# Altium schematic sheet Sync Demod.SchDoc
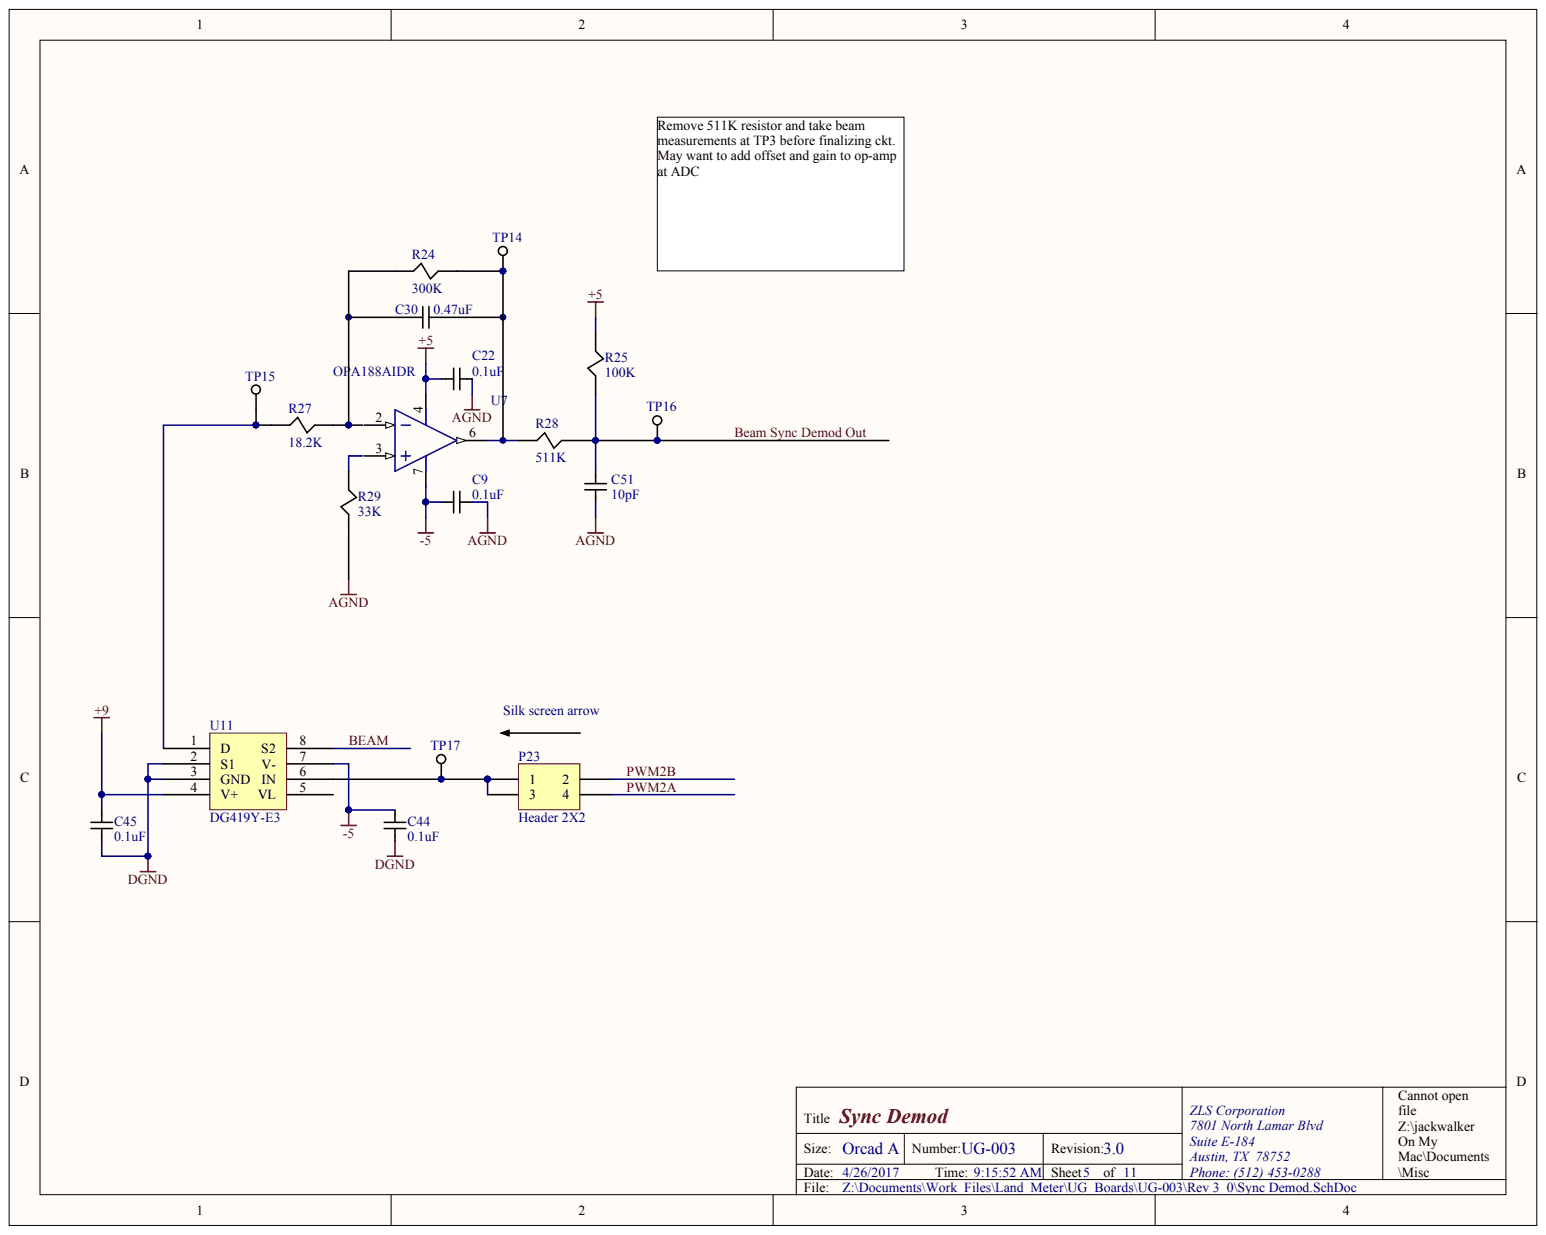
[diagram p0: Sync Demod.SchDoc]

## Parts
C9  0.1uF
C22  0.1uF
C30  0.47uF
C44  0.1uF
C45  0.1uF
C51  10pF
P23  Header 2X2  Connector Type: Header
R24  300K
R25  100K
R27  18.2K
R28  511K
R29  33K
TP14  (no value)
TP15  (no value)
TP16  (no value)
TP17  (no value)
U7  OPA188AIDR
U11  DG419Y-E3

## Nets
+5: C22.1 R25.2 U7.4
+9: C45.1 U11.4(V+)
-5: C9.1 C44.1 U7.7 U11.7(V-)
AGND: C9.2 C22.2 C51.2 R29.1
DGND: C44.2 C45.2 U11.2(S1) U11.3(GND)
BEAM: U11.8(S2)
BEAM SYNC DEMOD OUT: C51.1 R25.1 R28.2 TP16.1
PWM2A: P23.4
PWM2B: P23.2
NetC30_1: C30.1 R24.1 R27.2
NetC30_2: C30.2 R24.2 R28.1 TP14.1 U7.6
NetP23_1: P23.1 P23.3 TP17.1 U11.6(IN)
NetR27_1: R27.1 TP15.1 U11.1(D)

Not connected: R29 2; U7 2 3; U11 5(VL)

## Library data of the parts
C9 C22 C44 C45 = (no symbol name): Manufacturer 1: Murata Electronics North America; Manufacturer Part Number 1: GRM21BR71E104KA01L; Description 1: CAP CER 0.1UF 25V X7R 0805; Category 1: Capacitors; Capacitance: 0.1µF; Tolerance: ±10%; Voltage - Rated: 25V; Temperature Coefficient: X7R; Supplier 1: Digi-Key; Supplier Part Number 1: 490-1673-1-ND; RoHS 1: RoHS Compliant; Stock 1: 897437; Pricing 1: 1=0.2, 10=0.145, 25=0.106, 50=0.0824, 100=0.0683, 250=0.05652, 500=0.04788, 1000=0.03964 (USD); Packaging: Cut Tape (CT); Part Status: Active; Operating Temperature: -55°C ~ 125°C; Features: -; Ratings: -; Applications: General Purpose; Mounting Type: Surface Mount, MLCC; Package / Case: 0805 (2012 Metric); Size / Dimension: 0.079" L x 0.049" W (2.00mm x 1.25mm); Height - Seated (Max): -; Thickness (Max): 0.053" (1.35mm); Lead Spacing: -; Lead Style: -; ComponentLink1URL: http://www.murata.com/~/media/webrenewal/support/library/catalog/products/capacitor/mlcc/c02e.ashx; ComponentLink1Description: http://www.murata.com/~/media/webrenewal/support/library/catalog/products/capacitor/mlcc/c02e.ashx
C30 = (no symbol name): Manufacturer 1: Murata Electronics North America; Manufacturer Part Number 1: GRM219R71E474KA88D; Description 1: CAP CER 0.47UF 25V X7R 0805; Category 1: Capacitors; Capacitance: 0.47µF; Tolerance: ±10%; Voltage - Rated: 25V; Temperature Coefficient: X7R; Supplier 1: Digi-Key; Supplier Part Number 1: 490-11584-1-ND; RoHS 1: RoHS Compliant; Stock 1: 32625; Pricing 1: 1=0.31, 10=0.219, 25=0.1792, 50=0.153, 100=0.1311, 250=0.10924, 500=0.09832, 1000=0.08303 (USD); Packaging: Cut Tape (CT); Part Status: Active; Operating Temperature: -55°C ~ 125°C; Features: -; Ratings: -; Applications: General Purpose; Mounting Type: Surface Mount, MLCC; Package / Case: 0805 (2012 Metric); Size / Dimension: 0.079" L x 0.049" W (2.00mm x 1.25mm); Height - Seated (Max): -; Thickness (Max): 0.037" (0.95mm); Lead Spacing: -; Lead Style: -; ComponentLink1URL: http://www.murata.com/~/media/webrenewal/support/library/catalog/products/capacitor/mlcc/c02e.ashx; ComponentLink1Description: http://www.murata.com/~/media/webrenewal/support/library/catalog/products/capacitor/mlcc/c02e.ashx
C51 = (no symbol name): Manufacturer 1: Murata Electronics North America; Manufacturer Part Number 1: GRM21A5C2D100JW01D; Description 1: CAP CER 10PF 200V C0G/NP0 0805; Category 1: Capacitors; Tolerance: ±5%; Voltage - Rated: 200V; Temperature Coefficient: C0G, NP0; Supplier 1: Digi-Key; Supplier Part Number 1: 490-14649-1-ND; RoHS 1: RoHS Compliant; Stock 1: 1350; Pricing 1: 1=0.29, 10=0.196, 25=0.1436, 50=0.1174, 100=0.0991, 250=0.08084, 500=0.06782, 1000=0.05999 (USD); Part Status: Active; Capacitance: 10pF; Operating Temperature: -55°C ~ 125°C; Features: -; Ratings: -; Applications: General Purpose; Failure Rate: -; Mounting Type: Surface Mount, MLCC; Package / Case: 0805 (2012 Metric); Size / Dimension: 0.079" L x 0.049" W (2.00mm x 1.25mm); Height - Seated (Max): -; Thickness (Max): 0.039" (1.00mm); Lead Spacing: -; Lead Style: -; ComponentLink1URL: http://search.murata.co.jp/Ceramy/image/img/A01X/G101/ENG/GRM21A5C2D100JW01-01.pdf; ComponentLink1Description: http://search.murata.co.jp/Ceramy/image/img/A01X/G101/ENG/GRM21A5C2D100JW01-01.pdf
P23 = (no symbol name): Manufacturer 1: TE Connectivity AMP Connectors; Manufacturer Part Number 1: 1-87215-0; Description 1: CONN HEADER VERT 2POS .100 30AU; Category 1: Connectors, Interconnects; Style: Board to Board or Cable; Shrouding: Unshrouded; Number of Positions: 2; Number of Positions Loaded: All; Row Spacing - Mating: 0.100" (2.54mm); Contact Length - Mating: 0.318" (8.08mm); Contact Length - Post: 0.125" (3.18mm); Overall Contact Length: 0.533" (13.55mm); Material Flammability Rating: UL94 V-0; Current Rating: 3A; Supplier 1: Digi-Key; Supplier Part Number 1: A26564-ND; RoHS 1: RoHS Compliant; Stock 1: 9703; Pricing 1: 1=1.42, 10=1.287, 50=1.1554, 100=1.1029, 250=0.99784, 500=0.91906, 1000=0.78777, 2500=0.73525 (USD); Packaging: Bulk; Part Status: Active; Contact Type: Male Pin; Pitch - Mating: -; Number of Rows: 2; Mounting Type: Through Hole; Termination: Solder; Fastening Type: Push-Pull; Insulation Height: 0.090" (2.29mm); Contact Shape: Circular; Contact Finish - Mating: Gold; Contact Finish Thickness - Mating: 30µin (0.76µm); Contact Finish - Post: Gold; Contact Material: Phosphor Bronze; Insulation Material: Thermoplastic; Features: -; Operating Temperature: -65°C ~ 105°C; Ingress Protection: -; Insulation Color: Black; Voltage Rating: -; Mated Stacking Heights: -; Contact Finish Thickness - Post: Flash; Applications: -; ComponentLink1URL: http://www.te.com/commerce/DocumentDelivery/DDEController?Action=srchrtrv&DocNm=87215&DocType=Customer+Drawing&DocLang=English; ComponentLink1Description: http://www.te.com/commerce/DocumentDelivery/DDEController?Action=srchrtrv&DocNm=87215&DocType=Customer+Drawing&DocLang=English
R24 = (no symbol name): Manufacturer 1: Vishay Dale; Manufacturer Part Number 1: CRCW0805300KFKEA; Description 1: RES SMD 300K OHM 1% 1/8W 0805; Category 1: Resistors; Tolerance: ±1%; Power (Watts): 0.125W, 1/8W; Temperature Coefficient: ±100ppm/°C; Supplier 1: Digi-Key; Supplier Part Number 1: 541-300KCDKR-ND; RoHS 1: RoHS Compliant; Stock 1: 30792; Pricing 1: 1=0.1, 10=0.042, 25=0.0304, 50=0.0232, 100=0.017, 250=0.013, 500=0.0104, 1000=0.00765 (USD); Packaging: Digi-Reel®; Part Status: Active; Resistance (Ohms): 300k; Composition: Thick Film; Features: Automotive AEC-Q200; Operating Temperature: -55°C ~ 155°C; Package / Case: 0805 (2012 Metric); Supplier Device Package: 0805; Size / Dimension: 0.079" L x 0.049" W (2.00mm x 1.25mm); Height - Seated (Max): 0.020" (0.50mm); Failure Rate: -; ComponentLink1URL: http://www.vishay.com/docs/20035/dcrcwe3.pdf; ComponentLink1Description: http://www.vishay.com/docs/20035/dcrcwe3.pdf
R25 = (no symbol name): Manufacturer 1: Vishay Dale; Manufacturer Part Number 1: CRCW0805100KFKEA; Description 1: RES SMD 100K OHM 1% 1/8W 0805; Category 1: Resistors; Tolerance: ±1%; Power (Watts): 0.125W, 1/8W; Temperature Coefficient: ±100ppm/°C; Supplier 1: Digi-Key; Supplier Part Number 1: 541-100KCCT-ND; RoHS 1: RoHS Compliant; Stock 1: 231934; Pricing 1: 1=0.1, 10=0.042, 25=0.0304, 50=0.0232, 100=0.017, 250=0.013, 500=0.0104, 1000=0.00765 (USD); Packaging: Cut Tape (CT); Part Status: Active; Resistance (Ohms): 100k; Composition: Thick Film; Features: Automotive AEC-Q200; Operating Temperature: -55°C ~ 155°C; Package / Case: 0805 (2012 Metric); Supplier Device Package: 0805; Size / Dimension: 0.079" L x 0.049" W (2.00mm x 1.25mm); Height - Seated (Max): 0.020" (0.50mm); Failure Rate: -; ComponentLink1URL: http://www.vishay.com/docs/20035/dcrcwe3.pdf; ComponentLink1Description: http://www.vishay.com/docs/20035/dcrcwe3.pdf
R27 = (no symbol name): Manufacturer 1: Vishay Dale; Manufacturer Part Number 1: CRCW080518K2FKEA; Description 1: RES SMD 18.2K OHM 1% 1/8W 0805; Category 1: Resistors; Tolerance: ±1%; Power (Watts): 0.125W, 1/8W; Temperature Coefficient: ±100ppm/°C; Supplier 1: Digi-Key; Supplier Part Number 1: 541-18.2KCCT-ND; RoHS 1: RoHS Compliant; Stock 1: 1350; Pricing 1: 1=0.1, 10=0.042, 25=0.0304, 50=0.0232, 100=0.017, 250=0.013, 500=0.0104, 1000=0.00765 (USD); Packaging: Cut Tape (CT); Part Status: Active; Resistance (Ohms): 18.2k; Composition: Thick Film; Features: Automotive AEC-Q200; Operating Temperature: -55°C ~ 155°C; Package / Case: 0805 (2012 Metric); Supplier Device Package: 0805; Size / Dimension: 0.079" L x 0.049" W (2.00mm x 1.25mm); Height - Seated (Max): 0.020" (0.50mm); Failure Rate: -; ComponentLink1URL: http://www.vishay.com/docs/20035/dcrcwe3.pdf; ComponentLink1Description: http://www.vishay.com/docs/20035/dcrcwe3.pdf
R28 = (no symbol name): Manufacturer 1: Vishay Dale; Manufacturer Part Number 1: CRCW0805511KFKEA; Description 1: RES SMD 511K OHM 1% 1/8W 0805; Category 1: Resistors; Tolerance: ±1%; Power (Watts): 0.125W, 1/8W; Temperature Coefficient: ±100ppm/°C; Supplier 1: Digi-Key; Supplier Part Number 1: 541-511KCCT-ND; RoHS 1: RoHS Compliant; Stock 1: 7865; Pricing 1: 1=0.1, 10=0.042, 25=0.0304, 50=0.0232, 100=0.017, 250=0.013, 500=0.0104, 1000=0.00765 (USD); Packaging: Cut Tape (CT); Part Status: Active; Resistance (Ohms): 511k; Composition: Thick Film; Features: Automotive AEC-Q200; Operating Temperature: -55°C ~ 155°C; Package / Case: 0805 (2012 Metric); Supplier Device Package: 0805; Size / Dimension: 0.079" L x 0.049" W (2.00mm x 1.25mm); Height - Seated (Max): 0.020" (0.50mm); Failure Rate: -; ComponentLink1URL: http://www.vishay.com/docs/20035/dcrcwe3.pdf; ComponentLink1Description: http://www.vishay.com/docs/20035/dcrcwe3.pdf
R29 = (no symbol name): Manufacturer 1: Vishay Dale; Manufacturer Part Number 1: CRCW080533K0FKEA; Description 1: RES SMD 33K OHM 1% 1/8W 0805; Category 1: Resistors; Tolerance: ±1%; Power (Watts): 0.125W, 1/8W; Temperature Coefficient: ±100ppm/°C; Supplier 1: Digi-Key; Supplier Part Number 1: 541-33.0KCDKR-ND; RoHS 1: RoHS Compliant; Stock 1: 24473; Pricing 1: 1=0.1, 10=0.042, 25=0.0304, 50=0.0232, 100=0.017, 250=0.013, 500=0.0104, 1000=0.00765 (USD); Packaging: Digi-Reel®; Part Status: Active; Resistance (Ohms): 33k; Composition: Thick Film; Features: Automotive AEC-Q200; Operating Temperature: -55°C ~ 155°C; Package / Case: 0805 (2012 Metric); Supplier Device Package: 0805; Size / Dimension: 0.079" L x 0.049" W (2.00mm x 1.25mm); Height - Seated (Max): 0.020" (0.50mm); Failure Rate: -; ComponentLink1URL: http://www.vishay.com/docs/20035/dcrcwe3.pdf; ComponentLink1Description: http://www.vishay.com/docs/20035/dcrcwe3.pdf
TP14-TP17 = (no symbol name): Manufacturer 1: Keystone Electronics; Manufacturer Part Number 1: 5001; Description 1: TEST POINT PC MINI .040"D BLACK; Category 1: Test and Measurement; Plating: Silver; Material - Insulation: Nylon 46, UL 94V-0; Material - Body: Phosphor Bronze; Supplier 1: Digi-Key; Supplier Part Number 1: 36-5001-ND; RoHS 1: RoHS Compliant; Stock 1: 883882; Pricing 1: 1=0.37, 10=0.316, 50=0.2298, 100=0.2209, 250=0.19832, 500=0.18932, 1000=0.1616, 2500=0.14424, 5000=0.13523 (USD); Packaging: Bulk; Part Status: Active; Type: PC Test Point, Miniature; Mounting Type: 0.040" (1.02mm) Hole Diameter; Color: Black; Size / Dimension: 0.100" Dia x 0.180" L (2.54mm x 4.57mm); Height: -; ComponentLink1URL: http://www.keyelco.com/product-pdf.cfm?p=1310; ComponentLink1Description: http://www.keyelco.com/product-pdf.cfm?p=1310
U7 = (no symbol name): Manufacturer 1: Texas Instruments; Description 1: IC OPAMP ZERO-DRIFT 2MHZ 8SOIC; Category 1: Integrated Circuits (ICs); Amplifier Type: Zero-Drift; Number of Circuits: 1; Output Type: Rail-to-Rail; Slew Rate: 0.8 V/µs; Current - Supply: 450µA; Current - Output / Channel: 18mA; Voltage - Supply, Single/Dual (±): 4 V ~ 36 V, ±2 V ~ 18 V; Supplier 2: Mouser; Supplier Part Number 2: 595-OPA188AIDR; Supplier 1: Digi-Key; Supplier Part Number 1: 296-41346-6-ND; Manufacturer Part Number 1: OPA188AIDR; RoHS 1: RoHS Compliant; Stock 1: 2913; Pricing 1: 1=2.52, 10=2.26, 100=1.8165, 500=1.49248, 1000=1.23662 (USD); Packaging: Digi-Reel®; Part Status: Active; -3db Bandwidth: -; Operating Temperature: -55°C ~ 150°C; Mounting Type: Surface Mount; Package / Case: 8-SOIC (0.154", 3.90mm Width); Supplier Device Package: 8-SOIC; ComponentLink1URL: http://www.ti.com/lit/ds/symlink/opa188.pdf; ComponentLink1Description: http://www.ti.com/lit/ds/symlink/opa188.pdf
U11 = (no symbol name): Manufacturer 1: Analog Devices Inc.; Manufacturer Part Number 1: ADG419BRZ-REEL7; Description 1: IC SWITCH SPDT 8SOIC; Category 1: Integrated Circuits (ICs); Switch Circuit: SPDT; Multiplexer/Demultiplexer Circuit: 2:1; Number of Circuits: 1; On-State Resistance (Max): 35 Ohm; Voltage - Supply, Single (V+): 12V; Voltage - Supply, Dual (V±): ±15V; Channel Capacitance (CS(off), CD(off)): 6pF; Current - Leakage (IS(off)) (Max): 250pA; Crosstalk: -90dB @ 1MHz; Supplier 2: Mouser; Supplier Part Number 2: 584-ADG419BRZ; Supplier 1: Digi-Key; Supplier Part Number 1: ADG419BRZ-REEL7CT-ND; RoHS 1: RoHS Compliant; Stock 1: 3290; Pricing 1: 1=3.63, 10=3.26, 100=2.6713, 500=2.27404 (USD); Packaging: Cut Tape (CT); Part Status: Active; Channel-to-Channel Matching (&Delta;Ron): -; Switch Time (Ton, Toff) (Max): -; -3db Bandwidth: -; Charge Injection: -; Operating Temperature: -40°C ~ 125°C (TA); Package / Case: 8-SOIC (0.154", 3.90mm Width); Supplier Device Package: 8-SOIC; ComponentLink1URL: http://www.analog.com/media/en/technical-documentation/data-sheets/ADG419.pdf; ComponentLink1Description: http://www.analog.com/media/en/technical-documentation/data-sheets/ADG419.pdf
